# Altium schematic sheet FPGA_02.SchDoc(FPGA_02)
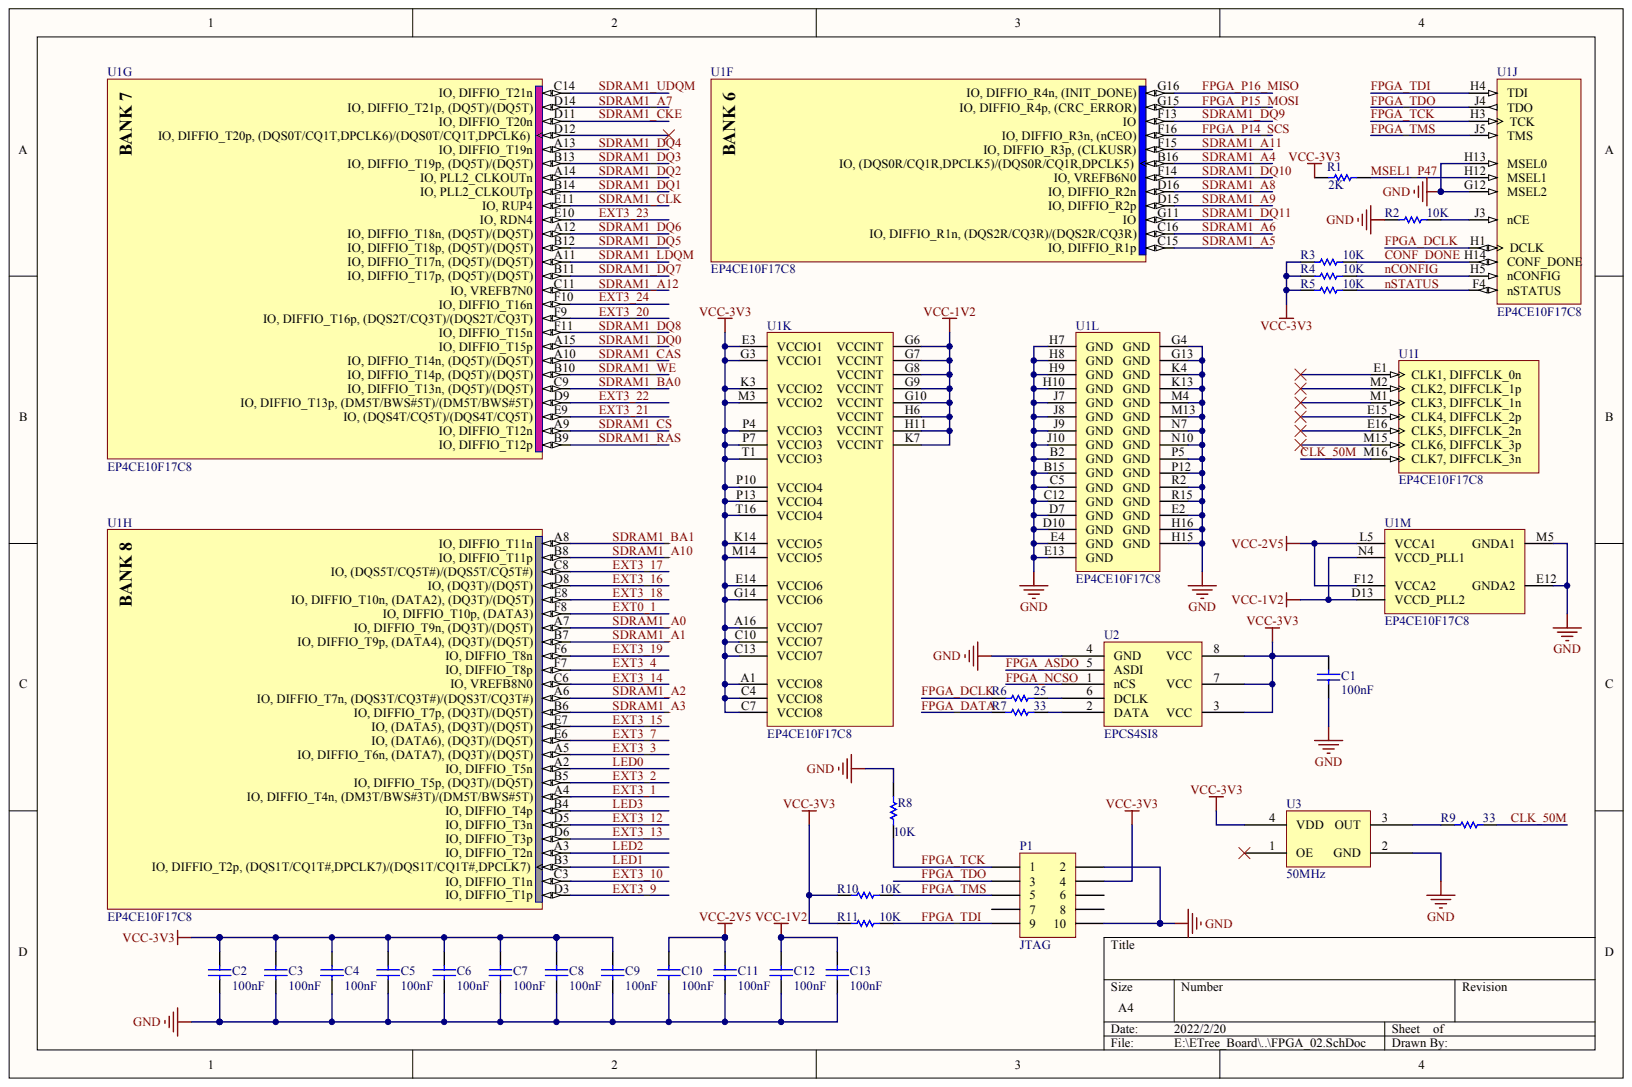
[diagram p0: FPGA_02.SchDoc]

## Parts
C1  value 100nF  (hidden comment: Cap)
C2  value 100nF  (hidden comment: Cap)
C3  value 100nF  (hidden comment: Cap)
C4  value 100nF  (hidden comment: Cap)
C5  value 100nF  (hidden comment: Cap)
C6  value 100nF  (hidden comment: Cap)
C7  value 100nF  (hidden comment: Cap)
C8  value 100nF  (hidden comment: Cap)
C9  value 100nF  (hidden comment: Cap)
C10  value 100nF  (hidden comment: Cap)
C11  value 100nF  (hidden comment: Cap)
C12  value 100nF  (hidden comment: Cap)
C13  value 100nF  (hidden comment: Cap)
P1  JTAG
R1  value 2K  (hidden comment: Res1)
R2  value 10K  (hidden comment: Res1)
R3  value 10K  (hidden comment: Res1)
R4  value 10K  (hidden comment: Res1)
R5  value 10K  (hidden comment: Res1)
R6  value 25  (hidden comment: Res1)
R7  value 33  (hidden comment: Res1)
R8  value 10K  (hidden comment: Res1)
R9  value 33  (hidden comment: Res1)
R10  value 10K  (hidden comment: Res1)
R11  value 10K  (hidden comment: Res1)
U1  EP4CE10F17C8  (parts F,G,H,I,J,K,L,M)
U2  EPCS4SI8
U3  50MHz

## Nets
GND: C1.2 C2.2 C3.2 C4.2 C5.2 C6.2 C7.2 C8.2 C9.2 C10.2 C11.2 C12.2 C13.2 P1.2 P1.10 R2.2 R8.2 U1.B2(GND) U1.B15(GND) U1.C5(GND) U1.C12(GND) U1.D7(GND) U1.D10(GND) U1.E2(GND) U1.E4(GND) U1.E12(GNDA2) U1.E13(GND) U1.G4(GND) U1.G12(MSEL2) U1.G13(GND) U1.H7(GND) U1.H8(GND) U1.H9(GND) U1.H10(GND) U1.H13(MSEL0) U1.H15(GND) U1.H16(GND) U1.J7(GND) U1.J8(GND) U1.J9(GND) U1.J10(GND) U1.K4(GND) U1.K13(GND) U1.M4(GND) U1.M5(GNDA1) U1.M13(GND) U1.N7(GND) U1.N10(GND) U1.P5(GND) U1.P12(GND) U1.R2(GND) U1.R15(GND) U2.4(GND) U3.2(GND)
VCC-1V2: C12.1 C13.1 U1.D13(VCCD_PLL2) U1.G6(VCCINT) U1.G7(VCCINT) U1.G8(VCCINT) U1.G9(VCCINT) U1.G10(VCCINT) U1.H6(VCCINT) U1.H11(VCCINT) U1.K7(VCCINT) U1.N4(VCCD_PLL1)
VCC-2V5: C10.1 C11.1 U1.F12(VCCA2) U1.L5(VCCA1)
VCC-3V3: C1.1 C2.1 C3.1 C4.1 C5.1 C6.1 C7.1 C8.1 C9.1 P1.4 R1.1 R3.2 R4.2 R5.2 R10.2 R11.2 U1.A1(VCCIO8) U1.A16(VCCIO7) U1.C4(VCCIO8) U1.C7(VCCIO8) U1.C10(VCCIO7) U1.C13(VCCIO7) U1.E3(VCCIO1) U1.E14(VCCIO6) U1.G3(VCCIO1) U1.G14(VCCIO6) U1.K3(VCCIO2) U1.K14(VCCIO5) U1.M3(VCCIO2) U1.M14(VCCIO5) U1.P4(VCCIO3) U1.P7(VCCIO3) U1.P10(VCCIO4) U1.P13(VCCIO4) U1.T1(VCCIO3) U1.T16(VCCIO4) U2.3(VCC) U2.7(VCC) U2.8(VCC) U3.4(VDD)
CLK_50M: R9.1 U1.M16(CLK7,_DIFFCLK_3n)
CONF_DONE: R3.1 U1.H14(CONF_DONE)
EXT0_1: U1.F8(IO,_DIFFIO_T10p,_(DATA3))
EXT3_1: U1.A4(IO,_DIFFIO_T4n,_(DM3T/BWS#3T)/(DM5T/BWS#5T))
EXT3_2: U1.B5(IO,_DIFFIO_T5p,_(DQ3T)/(DQ5T))
EXT3_3: U1.A5(IO,_DIFFIO_T6n,_(DATA7),_(DQ3T)/(DQ5T))
EXT3_4: U1.F7(IO,_DIFFIO_T8p)
EXT3_7: U1.E6(IO,_(DATA6),_(DQ3T)/(DQ5T))
EXT3_9: U1.D3(IO,_DIFFIO_T1p)
EXT3_10: U1.C3(IO,_DIFFIO_T1n)
EXT3_12: U1.D5(IO,_DIFFIO_T3n)
EXT3_13: U1.D6(IO,_DIFFIO_T3p)
EXT3_14: U1.C6(IO,_VREFB8N0)
EXT3_15: U1.E7(IO,_(DATA5),_(DQ3T)/(DQ5T))
EXT3_16: U1.D8(IO,_(DQ3T)/(DQ5T))
EXT3_17: U1.C8(IO,_(DQS5T/CQ5T#)/(DQS5T/CQ5T#))
EXT3_18: U1.E8(IO,_DIFFIO_T10n,_(DATA2),_(DQ3T)/(DQ5T))
EXT3_19: U1.F6(IO,_DIFFIO_T8n)
EXT3_20: U1.F9(IO,_DIFFIO_T16p,_(DQS2T/CQ3T)/(DQS2T/CQ3T))
EXT3_21: U1.E9(IO,_(DQS4T/CQ5T)/(DQS4T/CQ5T))
EXT3_22: U1.D9(IO,_DIFFIO_T13p,_(DM5T/BWS#5T)/(DM5T/BWS#5T))
EXT3_23: U1.E10(IO,_RDN4)
EXT3_24: U1.F10(IO,_DIFFIO_T16n)
FPGA_ASDO: U2.5(ASDI)
FPGA_DATA: R7.2
FPGA_DCLK: R6.2 U1.H1(DCLK)
FPGA_NCSO: U2.1(nCS)
FPGA_P14_SCS: U1.F16(IO,_DIFFIO_R3n,_(nCEO))
FPGA_P15_MOSI: U1.G15(IO,_DIFFIO_R4p,_(CRC_ERROR))
FPGA_P16_MISO: U1.G16(IO,_DIFFIO_R4n,_(INIT_DONE))
FPGA_TCK: P1.1 R8.1 U1.H3(TCK)
FPGA_TDI: P1.9 R11.1 U1.H4(TDI)
FPGA_TDO: P1.3 U1.J4(TDO)
FPGA_TMS: P1.5 R10.1 U1.J5(TMS)
LED0: U1.A2(IO,_DIFFIO_T5n)
LED1: U1.B3(IO,_DIFFIO_T2p,_(DQS1T/CQ1T#,DPCLK7)/(DQS1T/CQ1T#,DPCLK7))
LED2: U1.A3(IO,_DIFFIO_T2n)
LED3: U1.B4(IO,_DIFFIO_T4p)
MSEL1_P47: R1.2 U1.H12(MSEL1)
NCONFIG: R4.1 U1.H5(nCONFIG)
NSTATUS: R5.1 U1.F4(nSTATUS)
SDRAM1_A0: U1.A7(IO,_DIFFIO_T9n,_(DQ3T)/(DQ5T))
SDRAM1_A1: U1.B7(IO,_DIFFIO_T9p,_(DATA4),_(DQ3T)/(DQ5T))
SDRAM1_A2: U1.A6(IO,_DIFFIO_T7n,_(DQS3T/CQ3T#)/(DQS3T/CQ3T#))
SDRAM1_A3: U1.B6(IO,_DIFFIO_T7p,_(DQ3T)/(DQ5T))
SDRAM1_A4: U1.B16(IO,_(DQS0R/CQ1R,DPCLK5)/(DQS0R/CQ1R,DPCLK5))
SDRAM1_A5: U1.C15(IO,_DIFFIO_R1p)
SDRAM1_A6: U1.C16(IO,_DIFFIO_R1n,_(DQS2R/CQ3R)/(DQS2R/CQ3R))
SDRAM1_A7: U1.D14(IO,_DIFFIO_T21p,_(DQ5T)/(DQ5T))
SDRAM1_A8: U1.D16(IO,_DIFFIO_R2n)
SDRAM1_A9: U1.D15(IO,_DIFFIO_R2p)
SDRAM1_A10: U1.B8(IO,_DIFFIO_T11p)
SDRAM1_A11: U1.F15(IO,_DIFFIO_R3p,_(CLKUSR))
SDRAM1_A12: U1.C11(IO,_VREFB7N0)
SDRAM1_BA0: U1.C9(IO,_DIFFIO_T13n,_(DQ5T)/(DQ5T))
SDRAM1_BA1: U1.A8(IO,_DIFFIO_T11n)
SDRAM1_CAS: U1.A10(IO,_DIFFIO_T14n,_(DQ5T)/(DQ5T))
SDRAM1_CKE: U1.D11(IO,_DIFFIO_T20n)
SDRAM1_CLK: U1.E11(IO,_RUP4)
SDRAM1_CS: U1.A9(IO,_DIFFIO_T12n)
SDRAM1_DQ0: U1.A15(IO,_DIFFIO_T15p)
SDRAM1_DQ1: U1.B14(IO,_PLL2_CLKOUTp)
SDRAM1_DQ2: U1.A14(IO,_PLL2_CLKOUTn)
SDRAM1_DQ3: U1.B13(IO,_DIFFIO_T19p,_(DQ5T)/(DQ5T))
SDRAM1_DQ4: U1.A13(IO,_DIFFIO_T19n)
SDRAM1_DQ5: U1.B12(IO,_DIFFIO_T18p,_(DQ5T)/(DQ5T))
SDRAM1_DQ6: U1.A12(IO,_DIFFIO_T18n,_(DQ5T)/(DQ5T))
SDRAM1_DQ7: U1.B11(IO,_DIFFIO_T17p,_(DQ5T)/(DQ5T))
SDRAM1_DQ8: U1.F11(IO,_DIFFIO_T15n)
SDRAM1_DQ9: U1.F13(IO)
SDRAM1_DQ10: U1.F14(IO,_VREFB6N0)
SDRAM1_DQ11: U1.G11(IO)
SDRAM1_LDQM: U1.A11(IO,_DIFFIO_T17n,_(DQ5T)/(DQ5T))
SDRAM1_RAS: U1.B9(IO,_DIFFIO_T12p)
SDRAM1_UDQM: U1.C14(IO,_DIFFIO_T21n)
SDRAM1_WE: U1.B10(IO,_DIFFIO_T14p,_(DQ5T)/(DQ5T))
NetR2_1: R2.1 U1.J3(nCE)
NetR6_1: R6.1 U2.6(DCLK)
NetR7_1: R7.1 U2.2(DATA)
NetR9_2: R9.2 U3.3(OUT)

Not connected: P1 6 7 8; U1 D12(IO,_DIFFIO_T20p,_(DQS0T/CQ1T,DPCLK6)/(DQS0T/CQ1T,DPCLK6)) E1(CLK1,_DIFFCLK_0n) E15(CLK4,_DIFFCLK_2p) E16(CLK5,_DIFFCLK_2n) M1(CLK3,_DIFFCLK_1n) M2(CLK2,_DIFFCLK_1p) M15(CLK6,_DIFFCLK_3p); U3 1(OE)

## Library data of the parts
C1-C13 P1 R1-R11 U1-U3 = (no symbol name)
  C1-C13: Published: 8-Jun-2000; LatestRevisionDate: 17-Jul-2002; LatestRevisionNote: Re-released for DXP Platform.; PackageReference: RAD-0.3; PackageDescription: Radial Cap, Thru-Hole; 2 Leads; 0.3 in Pin Spacing
  P1: LatestRevisionDate: 17-Jul-2002; LatestRevisionNote: Re-released for DXP Platform.
  R1-R11: Published: 8-Jun-2000; LatestRevisionDate: 17-Jul-2002; LatestRevisionNote: Re-released for DXP Platform.; PackageReference: AXIAL-0.3; PackageDescription: Axial Device, Thru-Hole; 2 Leads; 0.3 in Pin Spacing
  U1: Published: 3-May-2010; DatasheetVersion: ver1.2, Mar-2010; PackageVersion: ver16.0, Dec-2009; PackageReference: FBGA256; PackageDescription: 256-Ball FineLine Ball Grid Array (FBGA256) - Option 1 - Wire Bond; Supplier 1: Digi-Key; Supplier Part Number 1: EP4CE10F17C8-ND; Code_JEDEC: MS-034-AAF-1; Code_IPC: BGA100P16X16-256; ComponentLink1Description: Manufacturer Link; ComponentLink1URL: http://www.altera.com/; ComponentLink2Description: Handbook; ComponentLink2URL: http://www.altera.com/literature/hb/cyclone-iv/cyclone4-handbook.pdf; ComponentLink3Description: Pinout Specification; ComponentLink3URL: http://www.altera.com/literature/lit-dp.jsp?category=cyc%204&showspreadsheet=y; ComponentLink4Description: Packaging Specification; ComponentLink4URL: http://www.altera.com/literature/ds/dspkg.pdf
  C1-C13 P1 R1-R11 U1: Publisher: Altium Limited
